# Altium schematic sheet NFC.SchDoc
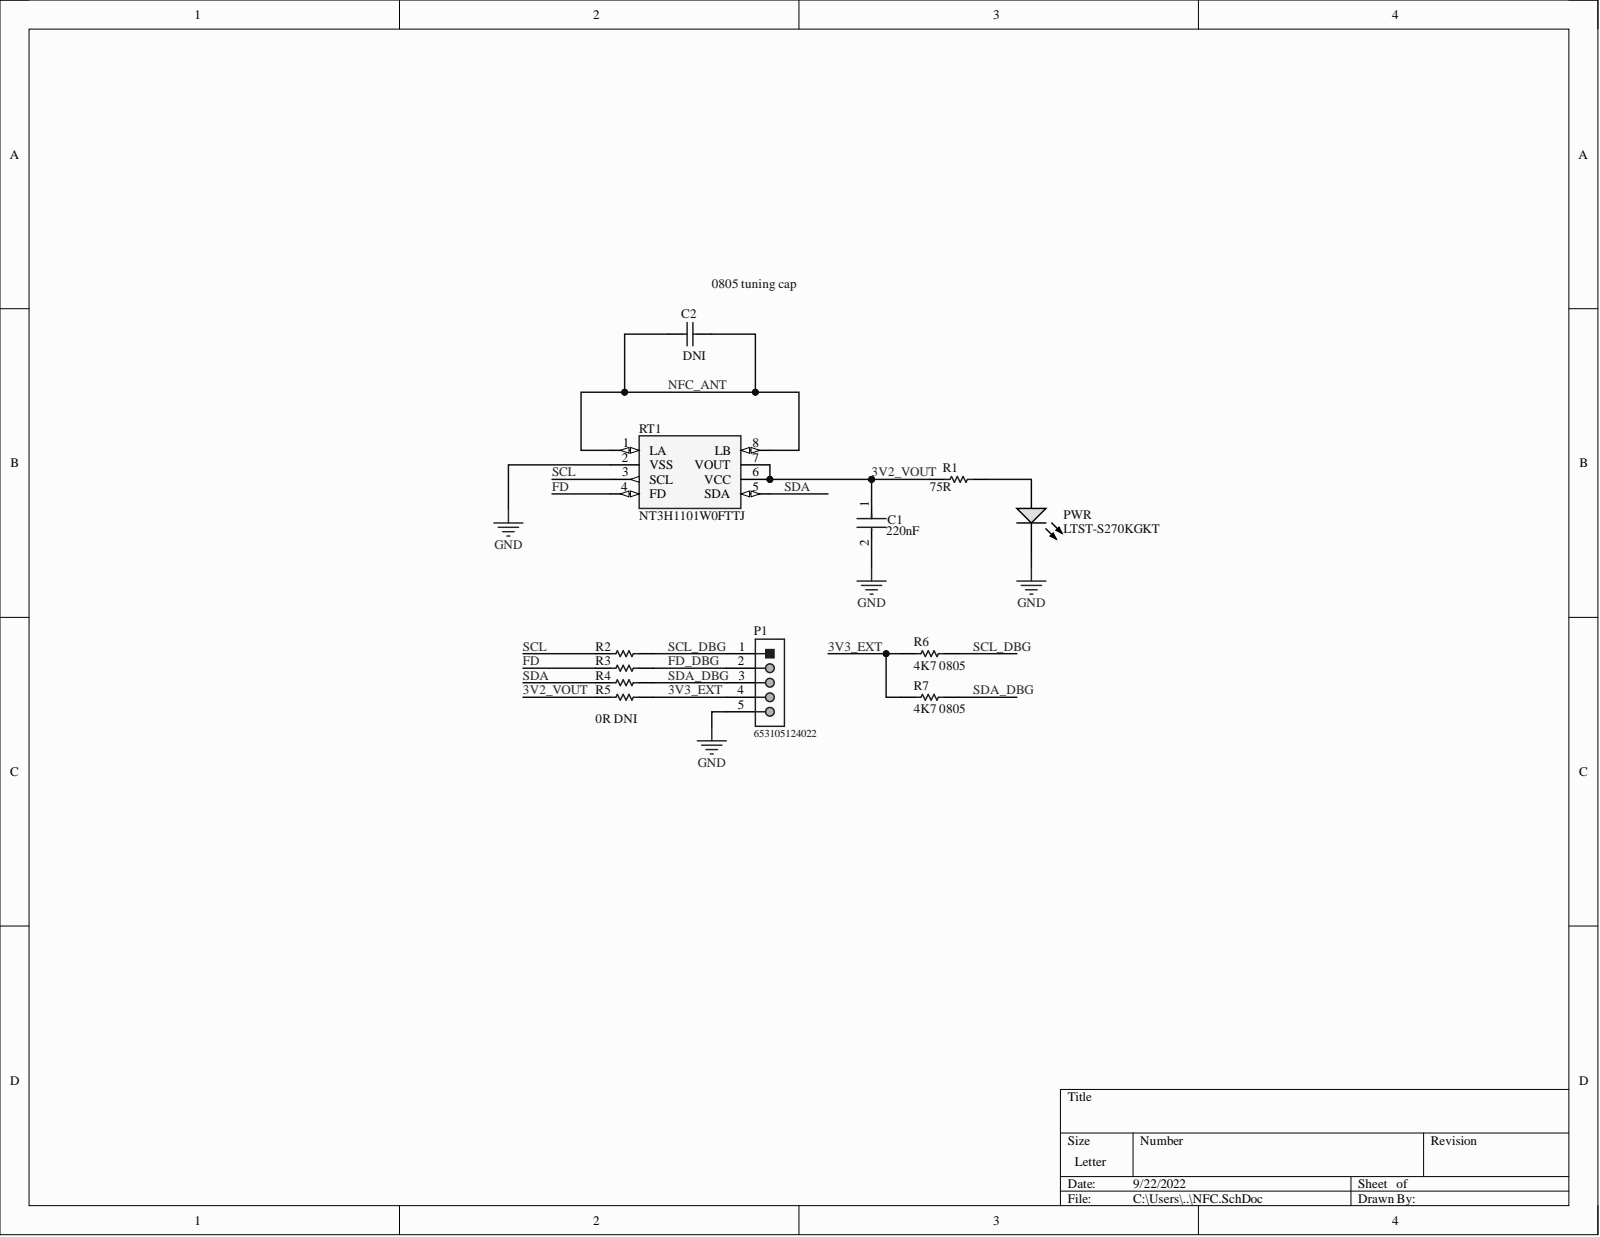
[diagram p0: NFC.SchDoc]

## Parts
C1  220nF  [FP-CC0805-0_85-MFG]
C2  DNI  [CAPC2013X140X50ML20T25]
P1  653105124022  [653105124022]
PWR  LTST-S270KGKT  [AVAG-HSMX-C190_V]
R1  75R  [RESC2013X50X30LL20T20]
R2  0R DNI  [RESC2013X60X35ML10T20]  (value not drawn)
R3  RC0805JR-070RL  [RESC2013X60X35ML10T20]  (value not drawn)
R4  RC0805JR-070RL  [RESC2013X60X35ML10T20]  (value not drawn)
R5  RC0805JR-070RL  [RESC2013X60X35ML10T20]  (value not drawn)
R6  4K7 0805  [YAGO-RC0805_M]
R7  4K7 0805  [YAGO-RC0805_M]
RT1  NT3H1101W0FTTJ  [SOP65P490X110-8N]

## Nets
GND: C1.2 P1.5 PWR.2 RT1.2(VSS)
3V2_VOUT: C1.1 R1.1 R5.1 RT1.6(VCC) RT1.7(VOUT)
3V3_EXT: P1.4 R5.2 R6.1 R7.1
FD: R3.1 RT1.4(FD)
FD_DBG: P1.2 R3.2
NFC_ANT: C2.1 C2.2 RT1.1(LA) RT1.8(LB)
SCL: R2.1 RT1.3(SCL)
SCL_DBG: P1.1 R2.2 R6.2
SDA: R4.1 RT1.5(SDA)
SDA_DBG: P1.3 R4.2 R7.2
NetPWR_1: PWR.1 R1.2

## Library data of the parts
C1 = CMP-03422-000685-1: CAP CER 0.22UF 16V X7R 0805; Manufacturer: Yageo; Manufacturer Part Number: CC0805KRX7R7BB224; Material: Ceramic; Tolerance: 0.1; Automotive: No; Capacitance: 220 nF; Category: Cap; Component Kind: Standard; ComponentLink2Description: Datasheet; ComponentLink2URL: http://www.yageo.com/documents/recent/UPY-GPHC_Y5V_6.3V-to-50V_8.pdf; Device Class L1: Passive Components; Device Class L2: Capacitors; Device Class L3: Ceramic Capacitors; Digikey Description: CAP CER 0.22UF 16V X7R 0805; Footprint Url: http://www.yageo.com/exep/pages/download/literatures/UPY-C_GEN_24.pdf; Height: 0.95mm; Ipc Land Pattern Name: CAPC200125X85; Lead Free: Yes; Library Name: Altium Content Vault; Mouser Description: Multilayer Ceramic Capacitors MLCC - SMD/SMT 220nF 16V X7R 10%; Octopart: https://octopart.com/cc0805krx7r7bb224-yageo-8376564; Package: CC0805-0.85; RoHS: TRUE; Temperature: -30°C to +85°C; Temperature Characteristic: X7R; Temperature Range High: +85°C; Temperature Range Low: -30°C; Value: 220 nF; Voltage Rating: 16 V
C2 = CMP-2007-04534-1: Manufacturer: AVX Interconnect / Elco; Part Number: 08051A1R0CAT2A; Component Kind: Standard; Library Name: Altium Content Vault
P1 = CMP-1502-00760-1: Male Shrouded Header WR-WTB, SMT, Vertical, pitch 1.25 mm, 1 x 5 position; Manufacturer: Wurth Elektronik; Contact Resistance: 20mOhm; Pitch: 1.25mm; Position: 1x5; Working Voltage: 125VAC; Component Kind: Standard; Datasheet URL: https://katalog.we-online.de/em/datasheet/6531xx124022.pdf; Gender: Male; Library Name: Altium Content Vault; Manufacturer URL: http://www.we-online.de; Mounting Technology: SMT; Operating Temperature: -40to85degC; Orientation: Vertical; Package Reference: 653105124022; Packaging: TapeandReel; Part Number: 653105124022; Publisher: WurthElektronik
PWR = CMP-1744-00003-1: LED Uni-Color Yellow, 65 mW, 20 mA, -40 to 85 degC, 2-Pin SMD, RoHS, Tape and Reel; Case\Package: 0603; Power Rating: 100 mW; Resistance: 390 Ohm; Tolerance: 1%; Voltage Rating: 50 V; Case Code (Imperial): 0603; Case Code (Metric): 1608; Component Kind: Standard; ComponentLink1Description: Manufacturer URL; ComponentLink1URL: http://www.avagotech.com/; ComponentLink2Description: Datasheet; ComponentLink2URL: http://docs.avagotech.com/docs/AV02-0551EN; ComponentLink3Description: Package Specification; ComponentLink3URL: http://www.fairchildsemi.com/dwg/MA/MA03D.pdf; DatasheetVersion: Mar-12; Height: 0.45 mm; Length: 1.6 mm; Library Name: Altium Content Vault; Max Operating Temperature: 155 degC; Min Operating Temperature: -55 degC; Mount: Surface Mount; Package Quantity: 5000; PackageDescription: 2-Pin Surface Mount Device, Body 1.6 x 0.8 mm, Height 0.9 mm; PackageReference: HSMX-C190; Packaging: Tape and Reel; Pins: 2; REACH SVHC: No SVHC; RoHSCompliant: true; Voltage Rating (DC): 50 V; Width: 0.8 mm
R1 = CMP-2001-04896-1: Manufacturer: Vishay Dale; Part Number: CRCW08050000Z0EA; Component Kind: Standard; Library Name: Altium Content Vault
R2-R5 = CMP-2100-03673-1: Chip Resistor, 0 Ohm, Jumper, +/- 5%, 0.125 W, -55 to 155 degC, 0805 (2012 Metric), RoHS, Tape and Reel; Manufacturer: Yageo; Power Rating: 125mW; Resistance: 0mOhm; Temperature Coefficient: 200ppm/degreesC; Voltage Rating: 150V; Case Code (Imperial): 0805; Case Code (Metric): 2012; Case/Package: 0805; Component Kind: Standard; Composition: ThickFilm; Contact Plating: Tin; Datasheet URL: http://www.yageo.com/NewPortal/yageodocoutput?fileName=/pdf/R-Chip/PYu-RC_Group_51_RoHS_L_5.pdf; Datasheet Version: Rev. 5, 10/2016; Depth: 1.25mm; Height: 0.5mm; Lead Free: LeadFree; Length: 2mm; Library Name: Altium Content Vault; Manufacturer URL: http://www.yageo.com/; Max Operating Temperature: 155degC; Military Standard: Not; Min Operating Temperature: -55degC; Number of Pins: 2; Package Description: 2-Pin Surface Mounted Device, Body 2 x 1.25 mm; Package Reference: 0805; Packaging: TapeandReel; Radiation Hardening: No; REACH SVHC: Unknown; RoHSCompliant: true; Termination: SMD/SMT; Tolerance: 5%; Voltage Rating (DC): 150V; Width: 1.25mm
R6 R7 = CMP-1568-00001-1: General Puprose Chip Resistor, 4700 Ohm +/- 1%, 0.125 W, -55 to 155 degC, 2-Pin SMD, RoHS, Tape and Reel; PartNumber: RC0805FR-074K7L; Power: 0.125 W; Tolerance: +/- 1%; Component Kind: Standard; ComponentLink1Description: Manufacturer URL; ComponentLink1URL: http://www.yageo.com/NewPortal/_en/index.jsp; ComponentLink2Description: Datasheet; ComponentLink2URL: http://www.yageo.com/documents/recent/PYu-RC_Group_51_RoHS_L-3.pdf; DatasheetVersion: Rev. 3; Library Name: Altium Content Vault; Mounting Technology: Surface Mount; PackageDescription: 2-Pin Surface Mount Device, Body 2 x 1.25 mm; Packing: Tape and Reel; Resistance: 4700 Ohm; RoHS: TRUE; Temperature Max: 155 degC; Temperature Min: -55 degC
RT1 = NT3H1101W0FTTJ: Thermistor; Manufacturer_Name: NXP; Arrow Part Number: NT3H1101W0FTTJ; Arrow Price/Stock: https://www.arrow.com/en/products/nt3h1101w0fttj/nxp-semiconductors?region=nac; Component Kind: Standard; Datasheet Link: https://ms.componentsearchengine.com/Datasheets/2/NT3H1101W0FTTJ.pdf; Height: 1.1mm; Manufacturer_Part_Number: NT3H1101W0FTTJ; Mouser Part Number: 771-NT3H1101W0FTTJ; Mouser Price/Stock: https://www.mouser.co.uk/ProductDetail/NXP-Semiconductors/NT3H1101W0FTTJ?qs=d7b8vw%252BBfo2DKYkZh36fFg%3D%3D
